# Altium schematic sheet Sheet1.SchDoc
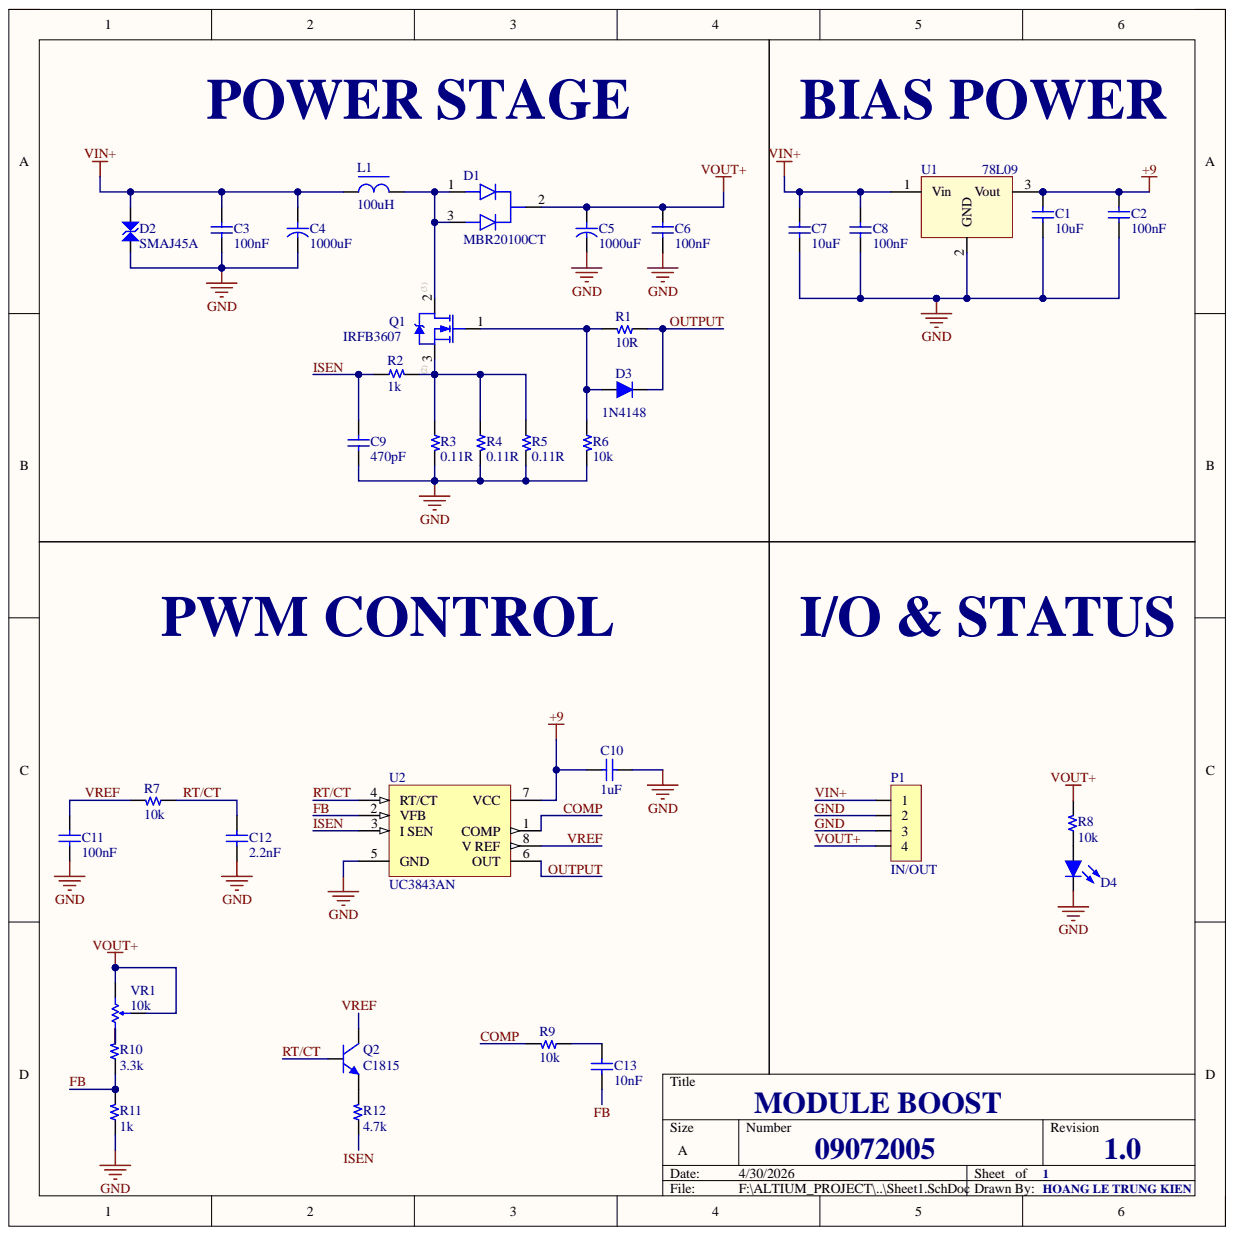
[diagram p0: Sheet1.SchDoc]

## Parts
C1  10uF  [C 0805]
C2  100nF  [C 0603]
C3  100nF  [C 1812]
C4  1000uF  [CYL/D.500/LS.200]
C5  1000uF  [CYL/D.500/LS.200]
C6  100nF  [C 1812]
C7  10uF  [C 0805]
C8  100nF  [C 0603]
C9  470pF  [C 0603]
C10  1uF  [C 0805]
C11  100nF  [C 0603]
C12  2.2nF  [C 0603]
C13  10nF  [C 0603]
D1  MBR20100CT  [TO254P1066X482X2286-3]
D2  SMAJ45A  [DO-214AA(SMB)]
D3  1N4148  [SOD323]
D4  Led  [Led 0805 RED]  (value not drawn)
L1  100uH  [L-DIP-M-26x12]
P1  IN/OUT  [HDR/Terminal/4]
Q1  IRFB3607  [TO-220]
Q2  C1815  [SOT-23]
R1  10R  [R 0805]
R2  1k  [R 0805]
R3  0.11R  [R 2512]
R4  0.11R  [R 2512]
R5  0.11R  [R 2512]
R6  10k  [R 0805]
R7  10k  [R 0805]
R8  10k  [R 0805]
R9  10k  [R 0805]
R10  3.3k  [R 0805]
R11  1k  [R 0805]
R12  4.7k  [R 0603]
U1  78L09  [SOT-89]
U2  UC3843AN  [SO8]
VR1  10k  [R VAR E]

## Nets
+9: C1.1 C2.2 C10.2 U1.3 U2.7(VCC)
COMP: R9.2 U2.1(COMP)
FB: C13.1 R10.1 R11.2 U2.2(VFB)
GND: C1.2 C2.1 C3.2 C4.2 C5.2 C6.2 C7.2 C8.1 C9.1 C10.1 C11.1 C12.1 D2.2 D4.2 P1.2 P1.3 R3.2 R4.2 R5.2 R6.2 R11.1 U1.2 U2.5(GND)
ISEN: C9.2 R2.1 R12.1 U2.3(I_SEN)
OUTPUT: D3.2 R1.1 U2.6(OUT)
RT/CT: C12.2 Q2.1 R7.1 U2.4(RT/CT)
VIN+: C3.1 C4.1 C7.1 C8.2 D2.1 L1.1 P1.1 U1.1
VOUT+: C5.1 C6.1 D1.2 P1.4 R8.2 VR1.1 VR1.2
VREF: C11.2 Q2.3 R7.2 U2.8(V_REF)
NetC13_2: C13.2 R9.1
NetD1_1: D1.1 D1.3 L1.2 Q1.3
NetD3_1: D3.1 Q1.1 R1.2 R6.1
NetD4_1: D4.1 R8.1
NetQ1_2: Q1.2 R2.2 R3.1 R4.1 R5.1
NetQ2_2: Q2.2 R12.2
NetR10_2: R10.2 VR1.3

## Library data of the parts
C1 C2 C8-C13 = (no symbol name): Capacitor non-pol; Component Kind: Standard; Library Name: KIENASIY123_LIB.IntLib
C3 C6 C7 = Capacitor NP: Capacitor non-pol; Component Kind: Standard; Library Name: KIENASIY123_LIB.IntLib
C4 C5 = (no symbol name): Capacitor pol; Component Kind: Standard; Library Name: KIENASIY123_LIB.IntLib
D1 = MBR20100CT: 20A, 100V, Planar Schottky; MF: Taiwan Semiconductor; Check_prices: https://www.snapeda.com/parts/MBR20100CT/taiwan/view-part/?ref=eda; Component Kind: Standard; Library Name: MBR20100CT.IntLib; MP: MBR20100CT; Package: TO-220AB-3 Taiwan Semiconductor; SnapEDA_Link: https://www.snapeda.com/parts/MBR20100CT/taiwan/view-part/?ref=snap
D2 = (no symbol name): Diode ESD/TVS; Component Kind: Standard; Library Name: KIENASIY123_LIB.IntLib
D3 = (no symbol name): Default Diode; Component Kind: Standard; Library Name: KIENASIY123_LIB.IntLib
D4 = Led: Typical LED; Component Kind: Standard; Library Name: KIENASIY123_LIB.IntLib
L1 = L-DIP-1: Inductor; Value: 150 mH; Component Kind: Standard; Library Name: Altium Library.IntLib
P1 = (no symbol name): Header, 4-Pin; Component Kind: Standard; Library Name: KIENASIY123_LIB.IntLib
Q1 = (no symbol name): Mosfet N; Component Kind: Standard; Library Name: KIENASIY123_LIB.IntLib
Q2 = (no symbol name): NPN Bipolar Transistor; Component Kind: Standard; Library Name: KIENASIY123_LIB.IntLib
R1-R7 R9-R12 = (no symbol name): Resistor; Component Kind: Standard; Library Name: KIENASIY123_LIB.IntLib
R8 = Resistor: Component Kind: Standard; Description: Resistor; Library Name: KIENASIY123_LIB.IntLib
U1 = (no symbol name): LDO Fixed Voltage regulator; Component Kind: Standard; Library Name: KIENASIY123_LIB.IntLib
U2 = UC3843AN: High-Performance Current Mode PWM Controller; Code_JEDEC: MO-001-AN; Component Kind: Standard; ComponentLink1Description: Manufacturer Link; ComponentLink1URL: http://www.st.com/; ComponentLink2Description: Datasheet; ComponentLink2URL: http://www.st.com/stonline/products/literature/ds/4298/uc3842a.pdf; DatasheetVersion: Mar-1999; LatestRevisionDate: 06-Jun-2005; LatestRevisionNote: Stylized 3D Model Added.; Library Name: Altium Library.IntLib; PackageDescription: DIP; 8 Leads; Row Spacing 7.62 mm; Pitch 2.54 mm; PackageReference: DIP8; Published: 24-Aug-2001; Publisher: Altium Limited
VR1 = (no symbol name): Resistor Variable; Component Kind: Standard; Library Name: KIENASIY123_LIB.IntLib
